# Altium schematic sheet Sheet1.SchDoc
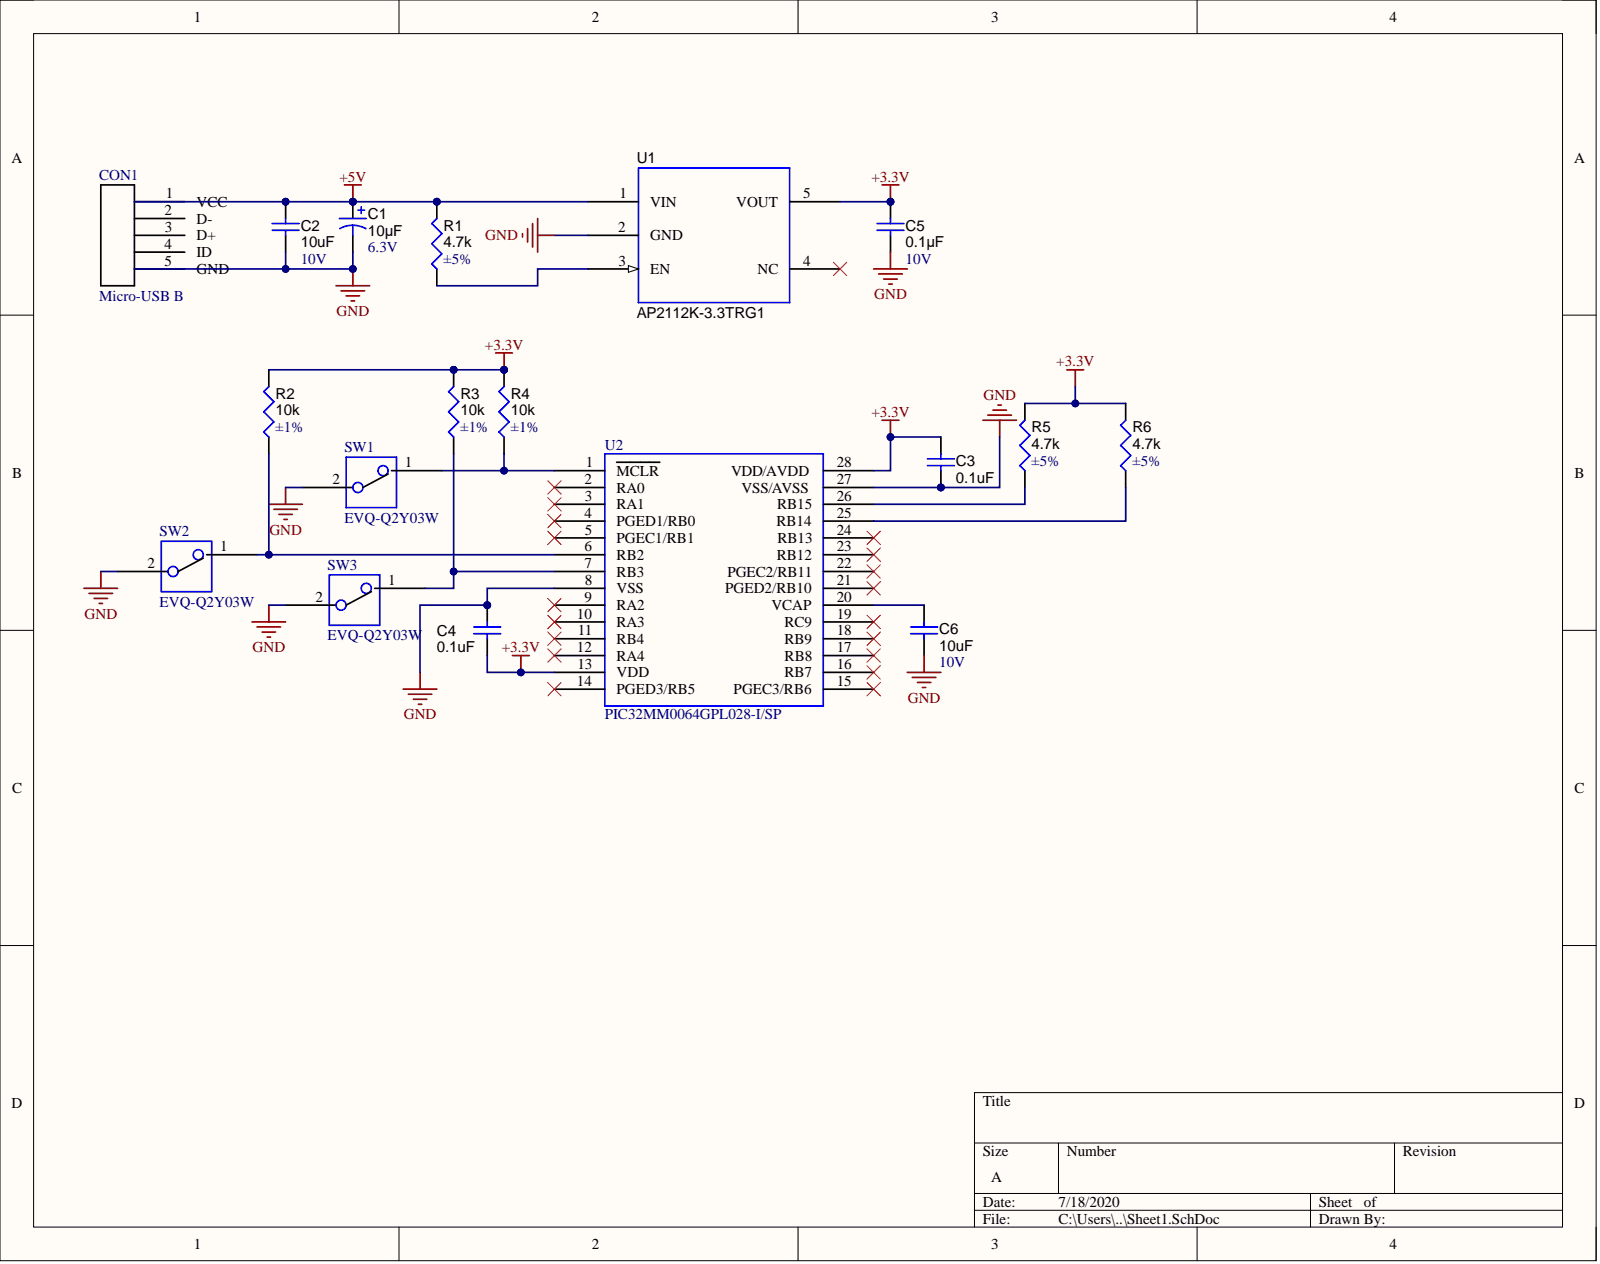
[diagram p0: Sheet1.SchDoc]

## Parts
C1  10µF  Parameters: 6.3V  [3216 (EIA-A)]
C2  10uF  Parameters: 10V  [0402_C]
C3  0.1uF  [0402_C]
C4  0.1uF  [0402_C]
C5  0.1µF  Parameters: 10V  [0402_C]
C6  10uF  Parameters: 10V  [0402_C]
CON1  Micro-USB B  [Micro-USB B]
R1  4.7k  Parameters: ±5%  [0402_R]
R2  10k  Parameters: ±1%  [0402_R]
R3  10k  Parameters: ±1%  [0402_R]
R4  10k  Parameters: ±1%  [0402_R]
R5  4.7k  Parameters: ±5%  [0402_R]
R6  4.7k  Parameters: ±5%  [0402_R]
SW1  EVQ-Q2Y03W  [EVQ-Q2Y03W]
SW2  EVQ-Q2Y03W  [EVQ-Q2Y03W]
SW3  EVQ-Q2Y03W  [EVQ-Q2Y03W]
U1  AP2112K-3.3TRG1  [SOT-25]
U2  PIC32MM0064GPL028-I/SP  [DIP28]  (value not drawn)

## Nets
+3.3V: C3.2 C4.1 C5.2 R2.2 R3.2 R4.2 R5.2 R6.2 U1.5(VOUT) U2.13(VDD) U2.28(VDD/AVDD)
+5V: C1.1 C2.2 CON1.1 R1.2 U1.1(VIN)
GND: C1.2 C2.1 C3.1 C4.2 C5.1 C6.1 CON1.5 SW1.2 SW2.2 SW3.2 U1.2(GND) U2.8(VSS) U2.27(VSS/AVSS)
NetC6_2: C6.2 U2.20(VCAP)
NetR1_1: R1.1 U1.3(EN)
NetR2_1: R2.1 SW2.1 U2.6(RB2)
NetR3_1: R3.1 SW3.1 U2.7(RB3)
NetR4_1: R4.1 SW1.1 U2.1(MCLR)
NetR5_1: R5.1 U2.26(RB15)
NetR6_1: R6.1 U2.25(RB14)

Not connected: CON1 2 3 4; U1 4(NC); U2 2(RA0) 3(RA1) 4(PGED1/RB0) 5(PGEC1/RB1) 9(RA2) 10(RA3) 11(RB4) 12(RA4) 14(PGED3/RB5) 15(PGEC3/RB6) 16(RB7) 17(RB8) 18(RB9) 19(RC9) 21(PGED2/RB10) 22(PGEC2/RB11) 23(RB12) 24(RB13)

## Library data of the parts
C1 = 1634: CAP TANT 10UF 6.3V 10% 1206; Manufacturer: Kemet; Manufacturer Part Number: T491A106K006AT; Part Category: Capacitors; Part Verified: True; Cost 1pc: 0.35; Cost 100pc: 0.154; Cost 1000pc: 0.1012; Current Inventory: 0; Database Table Name: Electronics_Parts; Notes: Fuel Cell Ect.; Primary Vendor Stock: 217271; SLInvtID: PDI-01634; Component Kind: Standard; ComponentLink1Description: Datasheet Document; ComponentLink1URL: \\astin.local\files\Lynntech\Departments\Operations\Instrumentation\Reference Materials\Datasheets\Capacitors\Tantalum\SMD\Kemet T491 Series Tantalum Capacitors.pdf; HelpURL: \\astin.local\files\Lynntech\Departments\Operations\Instrumentation\Reference Materials\Datasheets\Capacitors\Tantalum\SMD\Kemet T491 Series Tantalum Capacitors.pdf; Internal PN: 1634; RoHS Compliant: True; Signal Integrity: Cap; Simulation: CAP; Supplier 1: Digi-Key; Supplier Part Number 1: 399-3683-1-ND; Value: 10µF; Library Name: Lynntech Instrumentation.SVNDBLib; Verified By: John Lusher
C2 C6 = 9458: CAP CER 10UF 10V X5R 0402; Manufacturer: Samsung Electro-Mechanics; Manufacturer Part Number: CL05A106MP5NUNC; Part Category: Capacitors; Part Verified: False; Cost 1pc: 0.42; Cost 100pc: 0.19; Cost 1000pc: 0.122; Current Inventory: 0; Database Table Name: Electronics_Parts; Primary Vendor Stock: 4000000; SLInvtID: PDI-09458; Component Kind: Standard; ComponentLink1Description: Datasheet Document; Internal PN: 9458; RoHS Compliant: False; Signal Integrity: Cap; Simulation: CAP; Supplier 1: Digi-Key; Supplier Part Number 1: 1276-1450-1-ND; Value: 10uF; Library Name: Lynntech Instrumentation.SVNDBLib
C3 C4 = 9263: CAP CER 0.1UF 10V X5R 0402; Manufacturer: Samsung Electro-Mechanics; Manufacturer Part Number: CL05A104MP5NNNC; Part Category: Capacitors; Part Verified: False; Cost 1pc: 0.1; Cost 100pc: 1.06; Cost 1000pc: 5.95; Current Inventory: 0; Database Table Name: Electronics_Parts; Primary Vendor Stock: 5481315; SLInvtID: PDI-09263; Component Kind: Standard; ComponentLink1Description: Datasheet Document; Internal PN: 9263; RoHS Compliant: False; Signal Integrity: Cap; Simulation: CAP; Supplier 1: Digi-Key; Supplier Part Number 1: 1276-1443-1-ND; Value: 0.1uF; Library Name: Lynntech Instrumentation.SVNDBLib
C5 = 7637: CAP CER 0.1UF 10V 10% X5R 0402; Manufacturer: Kemet; Manufacturer Part Number: C0402C104K8PACTU; Part Category: Capacitors; Part Verified: True; Cost 1pc: 0.1; Cost 100pc: 0.0121; Cost 1000pc: 0.0066; Current Inventory: 0; Database Table Name: Electronics_Parts; Primary Vendor Stock: 307553; SLInvtID: PDI-07637; Component Kind: Standard; ComponentLink1Description: Datasheet Document; ComponentLink1URL: \\astin.local\files\Lynntech\Departments\Operations\Instrumentation\Reference Materials\Datasheets\Capacitors\Ceramic\SMD\KEM_C1006_X5R_SMD; HelpURL: \\astin.local\files\Lynntech\Departments\Operations\Instrumentation\Reference Materials\Datasheets\Capacitors\Ceramic\SMD\KEM_C1006_X5R_SMD; Internal PN: 7637; RoHS Compliant: True; Signal Integrity: Cap; Simulation: CAP; Supplier 1: Digi-Key; Supplier Part Number 1: 399-3027-1-ND; Value: 0.1µF; Library Name: Lynntech Instrumentation.SVNDBLib; Verified By: Mike Singleton
CON1 = 9543: USB - MICRO B USB 2.0 RECEPTACLE CONNECTOR 5 POSITION SURFACE MOUNT, RIGHT ANGLE; THROUGH HOLE; Manufacturer: Amphenol ICC (FCI); Manufacturer Part Number: 10118193-0001LF; Part Category: Connectors; Part Verified: False; Cost 1pc: 0.43; Cost 100pc: 0.31; Cost 1000pc: 0.27; Current Inventory: 0; Database Table Name: Electronics_Parts; SLInvtID: PDI-09543; Value: 10118193-0001LF; Component Kind: Standard; ComponentLink1Description: Datasheet Document; Internal PN: 9543; Primary Vendor Stock: 0; RoHS Compliant: False; Supplier 1: Digi-Key; Supplier Part Number 1: 609-4616-1-ND; Library Name: Lynntech Instrumentation.SVNDBLib
R1 R5 R6 = 3626: RES 4.7K OHM 1/10W 5% 0402 SMD; Manufacturer: Panasonic Electronic Components; Manufacturer Part Number: ERJ-2GEJ472X; Part Category: Resistors; Part Verified: True; Cost 1pc: 0.1; Cost 100pc: 0.0131; Cost 1000pc: 0.0048; Current Inventory: 0; Database Table Name: Electronics_Parts; Primary Vendor Stock: 3804446; SLInvtID: PDI-03626; Component Kind: Standard; ComponentLink1Description: Datasheet Document; ComponentLink1URL: \\astin.local\files\Lynntech\Departments\Operations\Instrumentation\Reference Materials\Datasheets\Resistors\SMT Resistor\ERJ Thick Film Chip Resistors.pdf; HelpURL: \\astin.local\files\Lynntech\Departments\Operations\Instrumentation\Reference Materials\Datasheets\Resistors\SMT Resistor\ERJ Thick Film Chip Resistors.pdf; Internal PN: 3626; RoHS Compliant: True; Signal Integrity: Res; Simulation: RESISTOR; Supplier 1: Digi-Key; Supplier Part Number 1: P4.7KJCT-ND; Value: 4.7k; Library Name: Lynntech Instrumentation.SVNDBLib; Verified By: John Lusher
R2-R4 = 8719: RES SMD 10K OHM 1% 1/5W 0402; Manufacturer: Vishay Dale; Manufacturer Part Number: RCS040210K0FKED; Part Category: Resistors; Part Verified: False; Cost 1pc: 0.13; Cost 100pc: 0.044; Cost 1000pc: 0.019; Current Inventory: 0; Database Table Name: Electronics_Parts; Primary Vendor Stock: 26000; SLInvtID: PDI-08719; Component Kind: Standard; ComponentLink1Description: Datasheet Document; Internal PN: 8719; RoHS Compliant: True; Signal Integrity: Res; Simulation: RES; Supplier 1: Digi-Key; Supplier Part Number 1: 541-2894-1-ND; Value: 10k; Library Name: Lynntech Instrumentation.SVNDBLib
SW1-SW3 = 9544: TACTILE SWITCH SPST-NO TOP ACTUATED SURFACE MOUNT; Manufacturer: Panasonic Electronic Components; Part Category: Batteries; Part Verified: False; Cost 1pc: 0; Database Table Name: Electronics_Parts; Component Kind: Standard; ComponentLink1Description: Datasheet Document; Cost 100pc: 0; Cost 1000pc: 0; Current Inventory: 0; Internal PN: 9544; Manufacturer Part Number: EVQ-Q2Y03W; Primary Vendor Stock: 0; RoHS Compliant: False; Supplier 1: Digi-Key; Supplier Part Number 1: P12961SCT-ND; Value: EVQ-Q2Y03W; Library Name: Lynntech Instrumentation.SVNDBLib
U1 = 9546: LINEAR VOLTAGE REGULATOR IC  1 OUTPUT  600MA SOT-25; Manufacturer: Diodes Incorporated; Part Category: Integrated Circuits (ICs); Part Verified: False; Cost 1pc: 0; Database Table Name: Electronics_Parts; Component Kind: Standard; ComponentLink1Description: Datasheet Document; Cost 100pc: 0; Cost 1000pc: 0; Current Inventory: 0; Internal PN: 9546; Manufacturer Part Number: AP2112K-3.3TRG1; Primary Vendor Stock: 0; RoHS Compliant: False; Supplier 1: Digi-Key; Supplier Part Number 1: AP2112K-3.3TRG1DICT-ND; Value: AP2112K-3.3TRG1; Library Name: Lynntech Instrumentation.SVNDBLib
U2 = 9542: MIPS32® MICROAPTIV™ PIC® 32MM MICROCONTROLLER IC 32-BIT 25MHZ 64KB (64K X 8) FLASH 28-SPDIP; Manufacturer: Microchip Technology; Part Category: Integrated Circuits (ICs); Part Verified: False; Cost 1pc: 1.6; Cost 100pc: 1.32; Current Inventory: 0; Database Table Name: Electronics_Parts; SLInvtID: PDI-09542; Component Kind: Standard; ComponentLink1Description: Datasheet Document; Cost 1000pc: 1.32; Internal PN: 9542; Manufacturer Part Number: PIC32MM0064GPL028-I/SP; Primary Vendor Stock: 0; RoHS Compliant: False; Supplier 1: Digi-Key; Supplier Part Number 1: PIC32MM0064GPL028-I/SP-ND; Value: PIC32MM0064GPL028-I/SP; Library Name: Lynntech Instrumentation.SVNDBLib
